# Altium schematic sheet Sheet3.SchDoc(Sheet3)
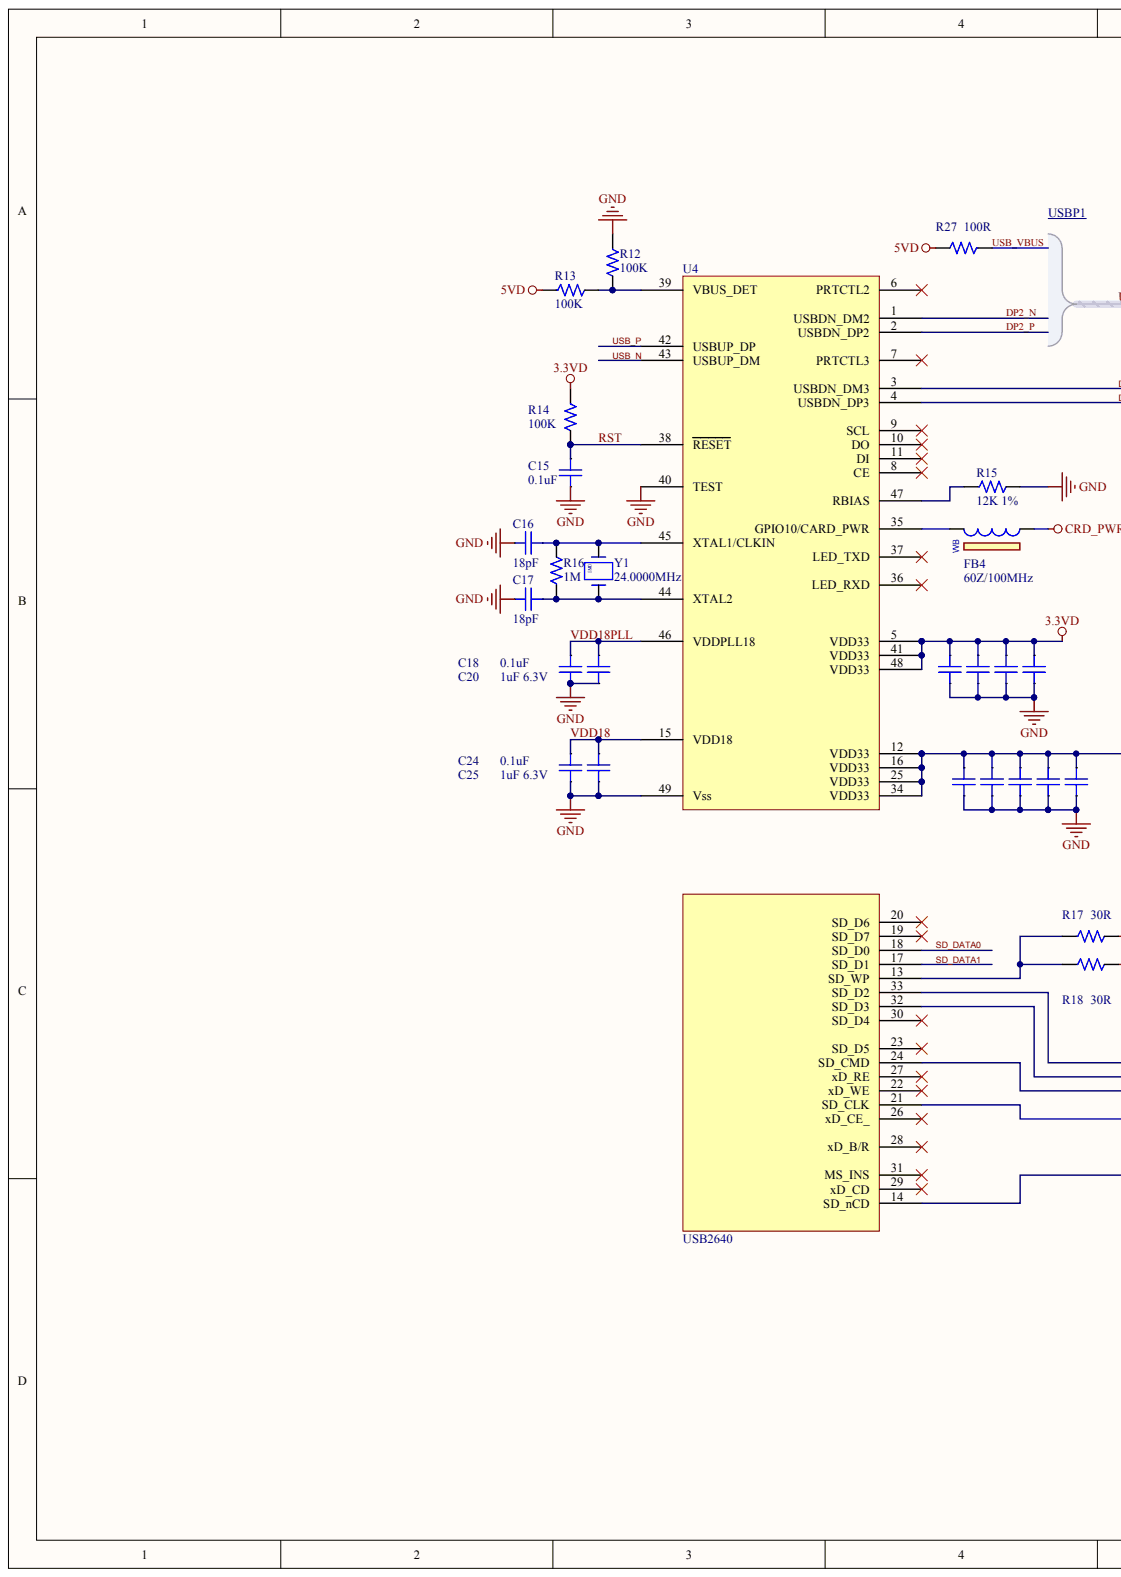
[diagram p0: Sheet3.SchDoc, left]
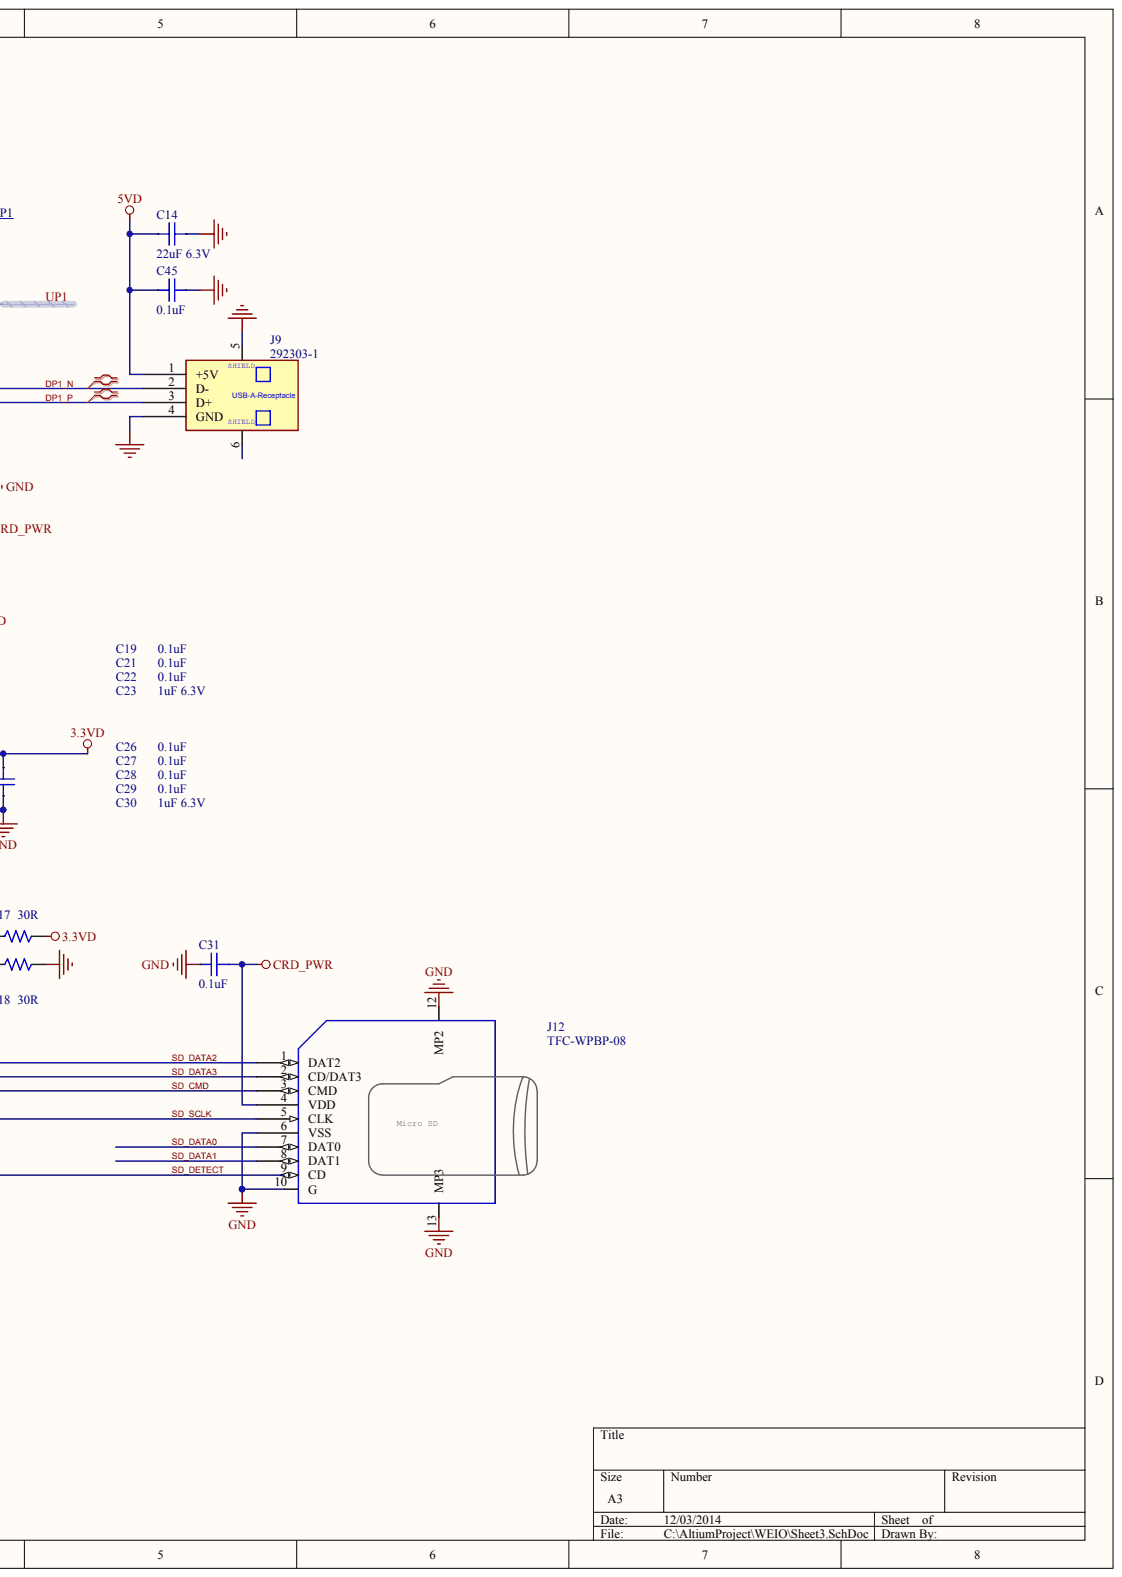
[diagram p1: Sheet3.SchDoc, right]

## Parts
C14  Voltage: 6.3V  (hidden comment: 22uF 6.3V)
C15  value 0.1uF  (hidden comment: 100nF)
C16  18pF
C17  18pF
C18  value 0.1uF  (hidden comment: 100nF)
C19  value 0.1uF  (hidden comment: 100nF)
C20  1uF 6.3V  Voltage: 6.3V
C21  value 0.1uF  (hidden comment: 100nF)
C22  value 0.1uF  (hidden comment: 100nF)
C23  1uF 6.3V
C24  value 0.1uF  (hidden comment: 100nF)
C25  1uF 6.3V  Voltage: 6.3V
C26  value 0.1uF  (hidden comment: 100nF)
C27  value 0.1uF  (hidden comment: 100nF)
C28  value 0.1uF  (hidden comment: 100nF)
C29  value 0.1uF  (hidden comment: 100nF)
C30  1uF 6.3V
C31  value 0.1uF  (hidden comment: 100nF)
C45  value 0.1uF  (hidden comment: 100nF)
FB4  60Z/100MHz
J9  292303-1
J12  TFC-WPBP-08
R12  100K
R13  100K
R14  100K
R15  Tolerance: 1%  (hidden comment: 12K 1%)
R16  1M
R17  30R
R18  30R
R27  100R
U4  USB2640
Y1  24.0000MHz

## Nets
3.3VD: C19.1 C21.1 C22.1 C23.1 C26.1 C27.1 C28.1 C29.1 C30.1 R14.1 R17.2 U4.5(VDD33) U4.12(VDD33) U4.16(VDD33) U4.25(VDD33) U4.34(VDD33) U4.41(VDD33) U4.48(VDD33)
5VD: C14.1 C45.1 J9.1(+5V) R13.1 R27.2
CRD_PWR: C31.1 FB4.1 J12.4(VDD)
GND: C14.2 C15.2 C16.2 C17.1 C18.2 C19.2 C20.2 C21.2 C22.2 C23.2 C24.2 C25.2 C26.2 C27.2 C28.2 C29.2 C30.2 C31.2 C45.2 J9.4(GND) J9.5 J12.6(VSS) J12.10(G) J12.12(MP2) J12.13(MP3) R12.1 R15.2 R18.2 U4.40(TEST) U4.49(Vss)
DP1_N: J9.2(D-) U4.3(USBDN_DM3)
DP1_P: J9.3(D+) U4.4(USBDN_DP3)
DP2_N: U4.1(USBDN_DM2)
DP2_P: U4.2(USBDN_DP2)
RST: C15.1 R14.2 U4.38(RESET)
SD_CMD: J12.3(CMD) U4.24(SD_CMD)
SD_DATA0: J12.7(DAT0) U4.18(SD_D0)
SD_DATA1: J12.8(DAT1) U4.17(SD_D1)
SD_DATA2: J12.1(DAT2) U4.33(SD_D2)
SD_DATA3: J12.2(CD/DAT3) U4.32(SD_D3)
SD_DETECT: J12.9(CD) U4.14(SD_nCD)
SD_SCLK: J12.5(CLK) U4.21(SD_CLK)
USB_N: U4.43(USBUP_DM)
USB_P: U4.42(USBUP_DP)
USB_VBUS: R27.1
VDD18: C24.1 C25.1 U4.15(VDD18)
VDD18PLL: C18.1 C20.1 U4.46(VDDPLL18)
NetC16_1: C16.1 R16.2 U4.45(XTAL1/CLKIN) Y1.1
NetC17_2: C17.2 R16.1 U4.44(XTAL2) Y1.3
NetFB4_2: FB4.2 U4.35(GPIO10/CARD_PWR)
NetR12_2: R12.2 R13.2 U4.39(VBUS_DET)
NetR15_1: R15.1 U4.47(RBIAS)
NetR17_1: R17.1 R18.1 U4.13(SD_WP)

Not connected: J9 6; U4 6(PRTCTL2) 7(PRTCTL3) 8(CE) 9(SCL) 10(DO) 11(DI) 19(SD_D7) 20(SD_D6) 22(xD_WE) 23(SD_D5) 26(xD_CE_) 27(xD_RE) 28(xD_B/R) 29(xD_CD) 30(SD_D4) 31(MS_INS) 36(LED_RXD) 37(LED_TXD)

## Library data of the parts
C14 = (no symbol name): Part Number: 00000712; Manufacturer Part Number: JMK316B7226ML-T; Manufacturer: Taiyo Yuden; COMPHEIGHT: 1.6; Tolerance: +-20%; Allegro PCB Footprint: c1206; Komentaras: $=0,034 Rutronik(Samsung); Value: 22uF 6.3V; Part Type: Ceramic\3216; SMD: Yes; Distributor Part Number: 587-1334-2-ND; Distributor: Digi-Key; Price: 0.115; Package: 2000T&R; Main distributor: Rutronik; MOQ: 2000; Availability: in stock; ActivepartsID: http://www.yuden.co.jp/ut/product/pdf/djmk_e.pdf; Data: 19/11/2010; Datasheet: E:\pdf\KONDENSATORIAI\CER_SMD\djmk_e.pdf
C15 C18 C19 C21 C22 C24 C26-C29 C45 = (no symbol name): Part Number: 00005500; Manufacturer Part Number: C1005X5R1C104K; Manufacturer: TDK; Voltage: 16V; Tolerance: +-10%; PSpice: C; Part Type: Ceramic\1005; Availability: In Stock; RoHS: Yes; Datasheet: E:\pdf\KONDENSATORIAI\CER_smd\e412_c.pdf
C16 C17 = (no symbol name): Part Number: 00000158; COMPHEIGHT: 0.8; Allegro PCB Footprint: C1608_I; Voltage: 50V; Tolerance: +-5%; PSpice: C; SMD: Yes; Distributor Part Number: 1001201; Distributor: Elgerta; Price: 0.015; Part Type: Ceramic; Availability: In Stock; Value: 18pF
C20 C23 C25 C30 = (no symbol name): Part Number: 00000548W; Manufacturer Part Number: GRM188R60J105KA01D; Manufacturer: MURATA; COMPHEIGHT: 0.8; Tolerance: +-10%; Allegro PCB Footprint: C1608_I; Gaminys: EAR2; Komentaras: $; Part Type: Ceramic\1608; SMD: Yes; Distributor Part Number: 490-1550-2-ND; Distributor: Digi-Key; Price: 0.008; Package: 4000T&R; Availability: In Stock; ActivepartsID: http://search.murata.co.jp/Ceramy/image/img/PDF/ENG/GRM188R60J105KA01.pdf; Data: 2010-10-11; ComponentLink1URL: E:\pdf\KONDENSATORIAI\CER_smd\ENG/GRM188R60J105KA01.pdf
  C23 C30: Voltage: 6.3V
C31 = (no symbol name): Manufacturer: TDK; Manufacturer Part Number: C1005X5R1C104K; Part Number: 00005500; Voltage: 16V; Tolerance: +-10%; PSpice: C; Part Type: Ceramic\1005; Availability: In Stock; RoHS: Yes; Datasheet: E:\pdf\KONDENSATORIAI\CER_smd\e412_c.pdf
FB4 = (no symbol name): Part Number: 20000001; Manufacturer Part Number: MI1608-600; Manufacturer: Coilmaster Electronics Co,,Ltd.; COMPHEIGHT: 0.8; Tolerance: 25%; Allegro PCB Footprint: MI1608_I; PSpice: L; Lead time: 3-4w; Part Type: Inductor\Ferrite beads 1608; SMD: Yes; Price: 0.03; Availability: 3-4weeks; Main distributor: Coilmaster; MOQ: 4000; ActivepartsID: http://www.coilmaster.com.tw/Web%20Site%20Catalogue%202007/T-MB,MI/MI1608-LF-RoHS.pdf; Data: 2009-05-15; Value: 60Z/100MHz; Datasheet: E:\pdf\induktyvumai\smd\MI1608-600-3A-LF-Teltonika.pdf
J9 = (no symbol name): Part Number: 72300111W; Manufacturer: Tyco Electronics; Allegro PCB Footprint: TYCO_USB_A_RECEP_RA_292303; Gaminys: FWBD1301; Komentaras: $; VALUE: 292303-1; Part Type: Connector\USB A; Manufacturer Part Number: 292303-1; Distributor Part Number: A31726-ND; Distributor: Digi-Key; Price: 0.63442; Availability: in Stok; ActivepartsID: http://www.tycoelectronics.com/catalog/bin/TE.Connect?C=1&M=BYPN&TCPN=292303-1&RQPN=292303-1; Data: 2011-04-26; Datasheet: E:\pdf\JUNGTYS\TYCO\ENG_CD_292303_E5.pdf
J12 = (no symbol name): Part Number: 70000203W; Manufacturer: MORETHANALL; Allegro PCB Footprint: TFC_WPBP_08; Gaminys: 8DEV; Komentaras: $; Part Type: Connector\micro SD CARD; SMD: Yes; Manufacturer Part Number: TFC-WPBP-08; Data: 2013-06-06; Datasheet: E:\pdf\JUNGTYS\107971654c901d8921f4c.pdf
R12-R14 = (no symbol name): Part Number: 10011128; Value: 100K
R15 = (no symbol name): Part Number: 10012086; Manufacturer Part Number: RC0402FR-12KL; Manufacturer: YAGEO; COMPHEIGHT: 0.35; Power: 1/16W; Allegro PCB Footprint: R0402,R1005_TM2; PSpice: R; Komentaras: $; Value: 12K 1%; Part type: SMD\0402_1%; SMD: Yes; Distributor Part Number: 311-12.0KLRTR-ND; Distributor: Digi-Key; Price: 0.00243; Package: 10000T&R; Availability: In Stock; Data: 22/02/2011; Datasheet: E:\pdf\rezistoriai\PSG_R-chip_Yageo_2005.pdf
R16 = (no symbol name): Part Number: 10011180; Manufacturer Part Number: RC0402JR-071ML; Manufacturer: YAGEO; COMPHEIGHT: 0.35; Power: 1/16W; Tolerance: 5%; Allegro PCB Footprint: R1005_TM2; PSpice: R; Value: 1M; Part type: SMD\0402; SMD: Yes; Distributor Part Number: 311-1.0MJRTR-ND; Distributor: Digi-Key; Price: 0.0057; Package: 10000T&R; Availability: In Stock; ActivepartsID: http://www.yageo.com/pdf/Pu-RC0402_51_PbFree_L_2.pdf; Data: 21/04/2009; Datasheet: E:\pdf\rezistoriai\PSG_R-chip_Yageo_2005.pdf
R17 R18 = (no symbol name): Part Number: 10011021W; Manufacturer Part Number: RC0402JR-0730RL; Manufacturer: YAGEO; COMPHEIGHT: 0.35; Power: 1/16W; Tolerance: 5%; Allegro PCB Footprint: R1005_TM2; PSpice: R; Gaminys: EAR2; Komentaras: $; Value: 30R; Part type: SMD\0402; SMD: Yes; Distributor Part Number: 311-30JRTR-ND; Distributor: Digi-Key; Price: 0.00213; Package: 10000T&R; Availability: In Stock; ActivepartsID: http://www.yageo.com/pdf/Pu-RC0402_51_PbFree_L_2.pdf; Data: 17/02/2010; Datasheet: E:\pdf\rezistoriai\Pu-RC0402_51_PbFree_L_2.pdf
R27 = (no symbol name): Part Number: 10011040; Manufacturer Part Number: RC0402JR-100RL; Manufacturer: YAGEO; Power: 1/16W; Tolerance: 5%; PSpice: R; Value: 100R; Part type: SMD\0402; RoHS: Yes; Availability: In Stock; Datasheet: E:\pdf\rezistoriai\PSG_R-chip_Yageo_2005.pdf
Y1 = (no symbol name): Manufacturer Part Number: TXC - 7M-24.000MAAJ-T; Allegro PCB Footprint: abls_hc49_4h465x115; COMPHEIGHT: 4.2; Komentaras: $; Price: 0.21; Distributor: Digi-Key; Distributor Part Number: 535-9067-2-ND; SMD: Yes; Part Type: Quartz crystal\SMD; Availability: In Stock; ActivepartsID: http://www.abracon.com/Resonators/abls.pdf; Data: 2011-02-21; Value: 24.0000MHz; Datasheet: E:\pdf\osciliatoriai\ABLS.pdf
